# Altium schematic sheet power.SchDoc
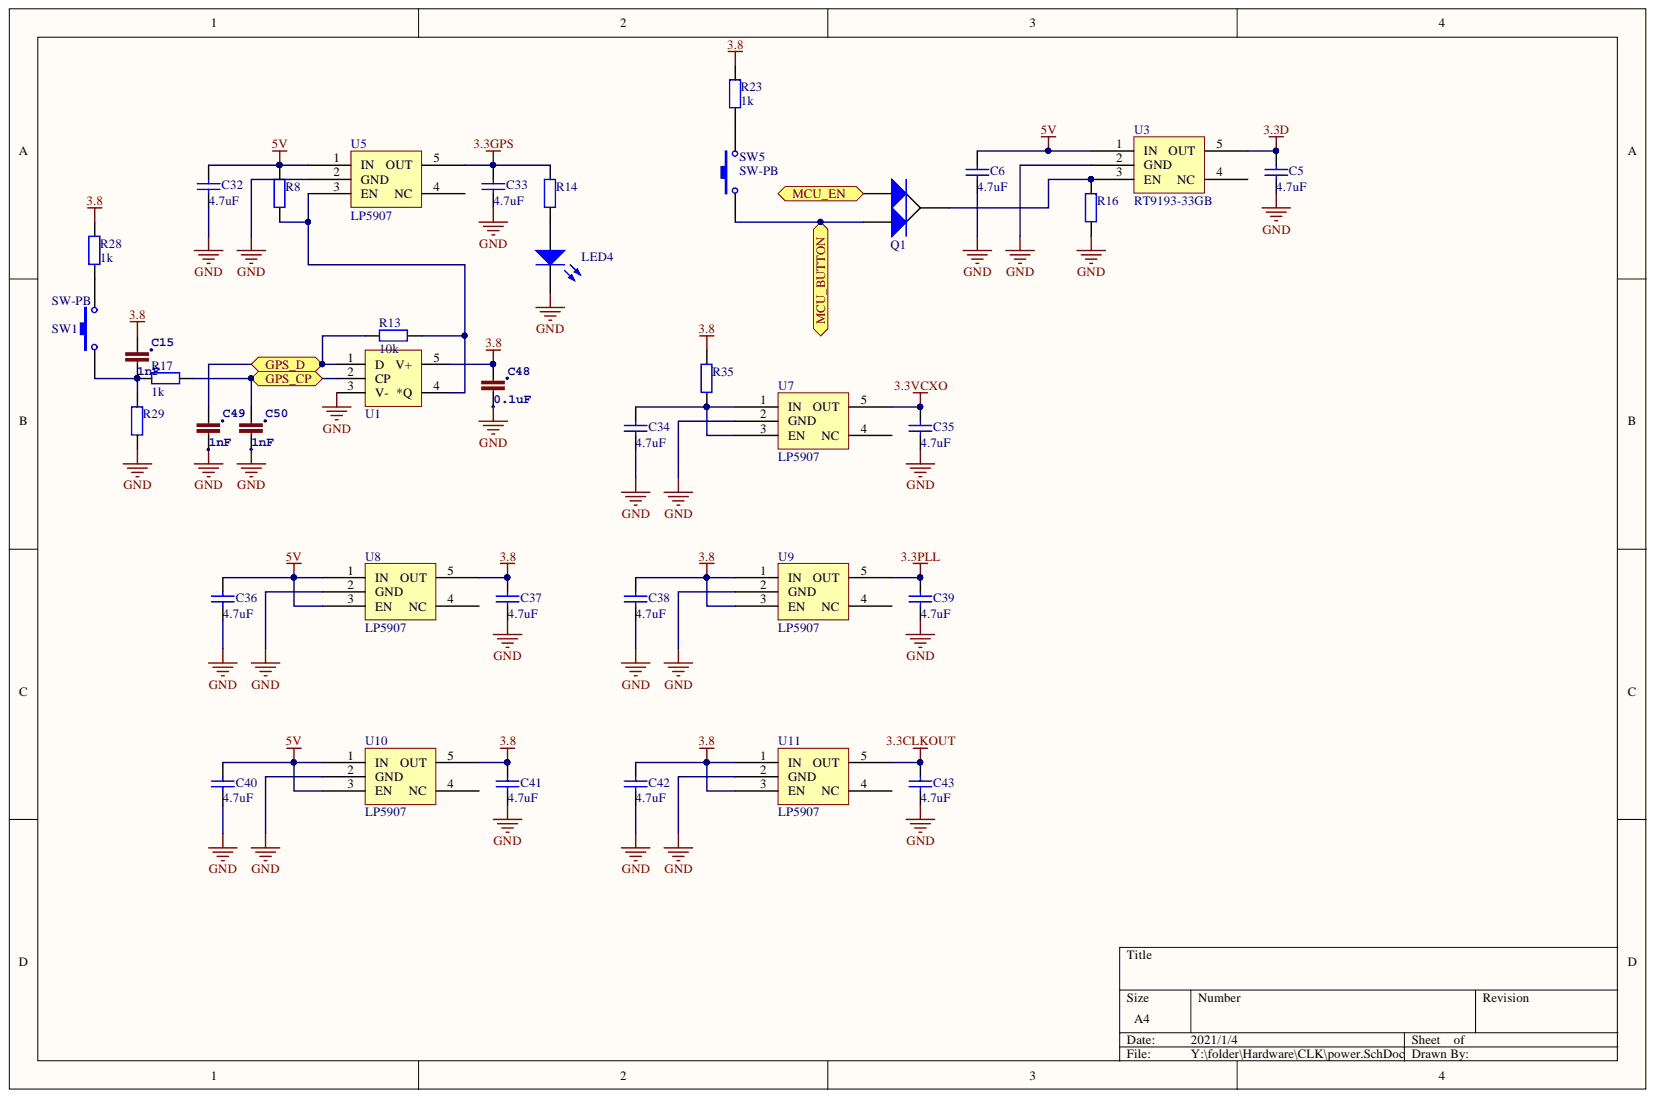
[diagram p0: power.SchDoc]

## Parts
C5  4.7uF  [0603L]
C6  4.7uF  [0603L]
C15  1nF  [0402]
C32  4.7uF  [0603L]
C33  4.7uF  [0603L]
C34  4.7uF  [0603L]
C35  4.7uF  [0603L]
C36  4.7uF  [0603L]
C37  4.7uF  [0603L]
C38  4.7uF  [0603L]
C39  4.7uF  [0603L]
C40  4.7uF  [0603L]
C41  4.7uF  [0603L]
C42  4.7uF  [0603L]
C43  4.7uF  [0603L]
C48  0.1uF  [0402]
C49  1nF  [0402]
C50  1nF  [0402]
LED4  LED0  [0603L]  (value not drawn)
Q1  BAT54C  [SOT-23B_L]  (value not drawn)
R8  (no value)  [0402]
R13  10k  [0402]
R14  (no value)  [0402]
R16  (no value)  [0402]
R17  1k  [0402]
R23  1k  [0402]
R28  1k  [0402]
R29  (no value)  [0402]
R35  (no value)  [0603L]
SW1  SW-PB  [SW3x4]
SW5  SW-PB  [SW3x4]
U1  74-1G80  [SOT23-5]  (value not drawn)
U3  RT9193-33GB  [SOT23-5]
U5  LP5907  [SOT23-5]
U7  LP5907  [SOT23-5]
U8  LP5907  [SOT23-5]
U9  LP5907  [SOT23-5]
U10  LP5907  [SOT23-5]
U11  LP5907  [SOT23-5]

## Ports
GPS_CP, GPS_D, MCU_BUTTON, MCU_EN

## Nets
3.3CLKOUT: C43.2 U11.5(OUT)
3.3D: C5.2 U3.5(OUT)
3.3GPS: C33.2 R14.1 U5.5(OUT)
3.3PLL: C39.2 U9.5(OUT)
3.3VCXO: C35.2 U7.5(OUT)
3.8: C15.1 C37.2 C38.2 C41.2 C42.2 C48.1 R23.2 R28.2 R35.1 U1.5(V+) U8.5(OUT) U9.1(IN) U9.3(EN) U10.5(OUT) U11.1(IN) U11.3(EN)
5V: C6.2 C32.2 C36.2 C40.2 R8.1 U3.1(IN) U5.1(IN) U8.1(IN) U8.3(EN) U10.1(IN) U10.3(EN)
GND: C5.1 C6.1 C32.1 C33.1 C34.1 C35.1 C36.1 C37.1 C38.1 C39.1 C40.1 C41.1 C42.1 C43.1 C48.2 C49.2 C50.2 LED4.2 R16.2 R29.1 U1.3(V-) U3.2(GND) U5.2(GND) U7.2(GND) U8.2(GND) U9.2(GND) U10.2(GND) U11.2(GND)
GPS_CP: C50.1 R17.1 U1.2(CP) ; port GPS_CP
GPS_D: C49.1 R13.1 U1.1(D) ; port GPS_D
MCU_BUTTON: Q1.2 SW5.1 ; port MCU_BUTTON
MCU_EN: Q1.1 ; port MCU_EN
NetC15_2: C15.2 R17.2 R29.2 SW1.1
NetC34_2: C34.2 R35.2 U7.1(IN) U7.3(EN)
NetLED4_1: LED4.1 R14.2
NetQ1_3: Q1.3 R16.1 U3.3(EN)
NetR8_2: R8.2 R13.2 U1.4(*Q) U5.3(EN)
NetR23_1: R23.1 SW5.2
NetR28_1: R28.1 SW1.2

Not connected: U3 4(NC); U5 4(NC); U7 4(NC); U8 4(NC); U9 4(NC); U10 4(NC); U11 4(NC)

## Library data of the parts
C5 C6 C32-C43 = Cap: Capacitor; Component Kind: Standard; LatestRevisionDate: 17-Jul-2002; LatestRevisionNote: Re-released for DXP Platform.; Library Name: RTC6705.SchLib; PackageDescription: Radial Cap, Thru-Hole; 2 Leads; 0.3 in Pin Spacing; PackageReference: RAD-0.3; Published: 8-Jun-2000; Signal Integrity: Cap; Simulation: CAP; Publisher: Altium Limited
C15 C48-C50 = CAP0402-CAP: <b>Capacitor</b> Standard 0603 ceramic capacitor, and 0.1" leaded capacitor.; PARTNO: CC0402ZRY5V7BB104; Component Kind: Standard; Library Name: pixhawk2.IntLib
LED4 = LED0: Typical INFRARED GaAs LED; Component Kind: Standard; LatestRevisionDate: 08-Jul-2005; LatestRevisionNote: Mech Layer 1 removed.; Library Name: Miscellaneous Devices.IntLib; PackageDescription: LED; 2 Leads; PackageReference: LED-0; Published: 8-Jun-2000; Simulation: LED0; Publisher: Altium Limited
R8 R14 R16 R29 R35 = Res2: Resistor; Value: 0R; Component Kind: Standard; LatestRevisionDate: 17-Jul-2002; LatestRevisionNote: Re-released for DXP Platform.; Library Name: Miscellaneous Devices.IntLib; PackageDescription: Axial Device, Thru-Hole; 2 Leads; 0.4 in Pin Spacing; PackageReference: AXIAL-0.4; Published: 8-Jun-2000; Signal Integrity: Res; Simulation: RESISTOR; Publisher: Altium Limited
R13 = Res2: Resistor; Value: 47k; Component Kind: Standard; LatestRevisionDate: 17-Jul-2002; LatestRevisionNote: Re-released for DXP Platform.; Library Name: Miscellaneous Devices.IntLib; PackageDescription: Axial Device, Thru-Hole; 2 Leads; 0.4 in Pin Spacing; PackageReference: AXIAL-0.4; Published: 8-Jun-2000; Signal Integrity: Res; Simulation: RESISTOR; Publisher: Altium Limited
R17 = Res2: Resistor; Component Kind: Standard; LatestRevisionDate: 17-Jul-2002; LatestRevisionNote: Re-released for DXP Platform.; Library Name: Miscellaneous Devices.IntLib; PackageDescription: Axial Device, Thru-Hole; 2 Leads; 0.4 in Pin Spacing; PackageReference: AXIAL-0.4; Published: 8-Jun-2000; Signal Integrity: Res; Simulation: RESISTOR; Publisher: Altium Limited
R23 R28 = Res2: Resistor; Value: 0Ohm; Component Kind: Standard; LatestRevisionDate: 17-Jul-2002; LatestRevisionNote: Re-released for DXP Platform.; Library Name: Miscellaneous Devices.IntLib; PackageDescription: Axial Device, Thru-Hole; 2 Leads; 0.4 in Pin Spacing; PackageReference: AXIAL-0.4; Published: 8-Jun-2000; Signal Integrity: Res; Simulation: RESISTOR; Publisher: Altium Limited
SW1 SW5 = SW-PB: Switch; Component Kind: Standard; LatestRevisionDate: 17-Jul-2002; LatestRevisionNote: Re-released for DXP Platform.; Library Name: Miscellaneous Devices.IntLib; PackageDescription: Switch; 2 Leads; PackageReference: SPST-2; Published: 24-Mar-1999; Publisher: Altium Limited
U3 = LP5907: Component Kind: Standard; Library Name: RTC6705.SchLib
Q1 = BAT54C: Component Kind: Standard; Library Name: sch.SchLib
U1 = 74-1G80: Component Kind: Standard; Library Name: sch.SchLib
U5 U7-U11 = LP5907: Component Kind: Standard; Library Name: RTC6705.SchLib
